# Altium schematic sheet CURRENT_SOURCE.SchDoc
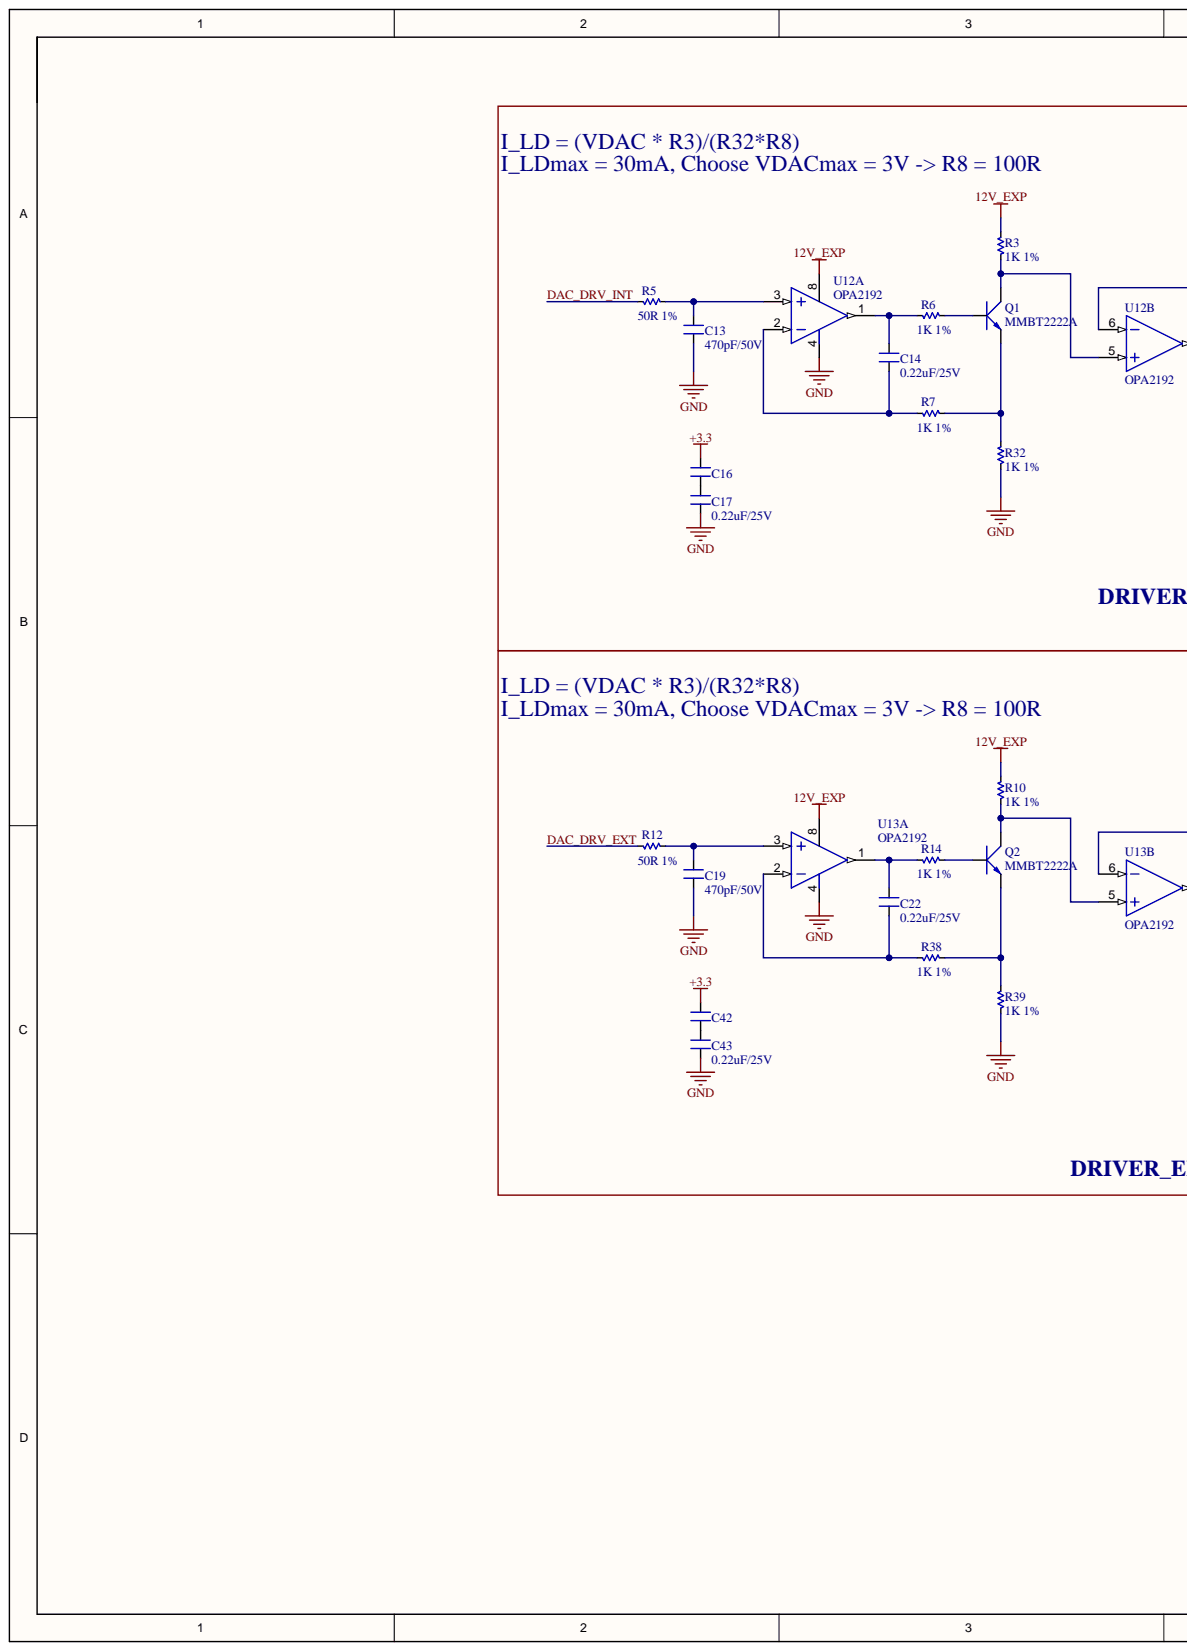
[diagram p0: CURRENT_SOURCE.SchDoc, left]
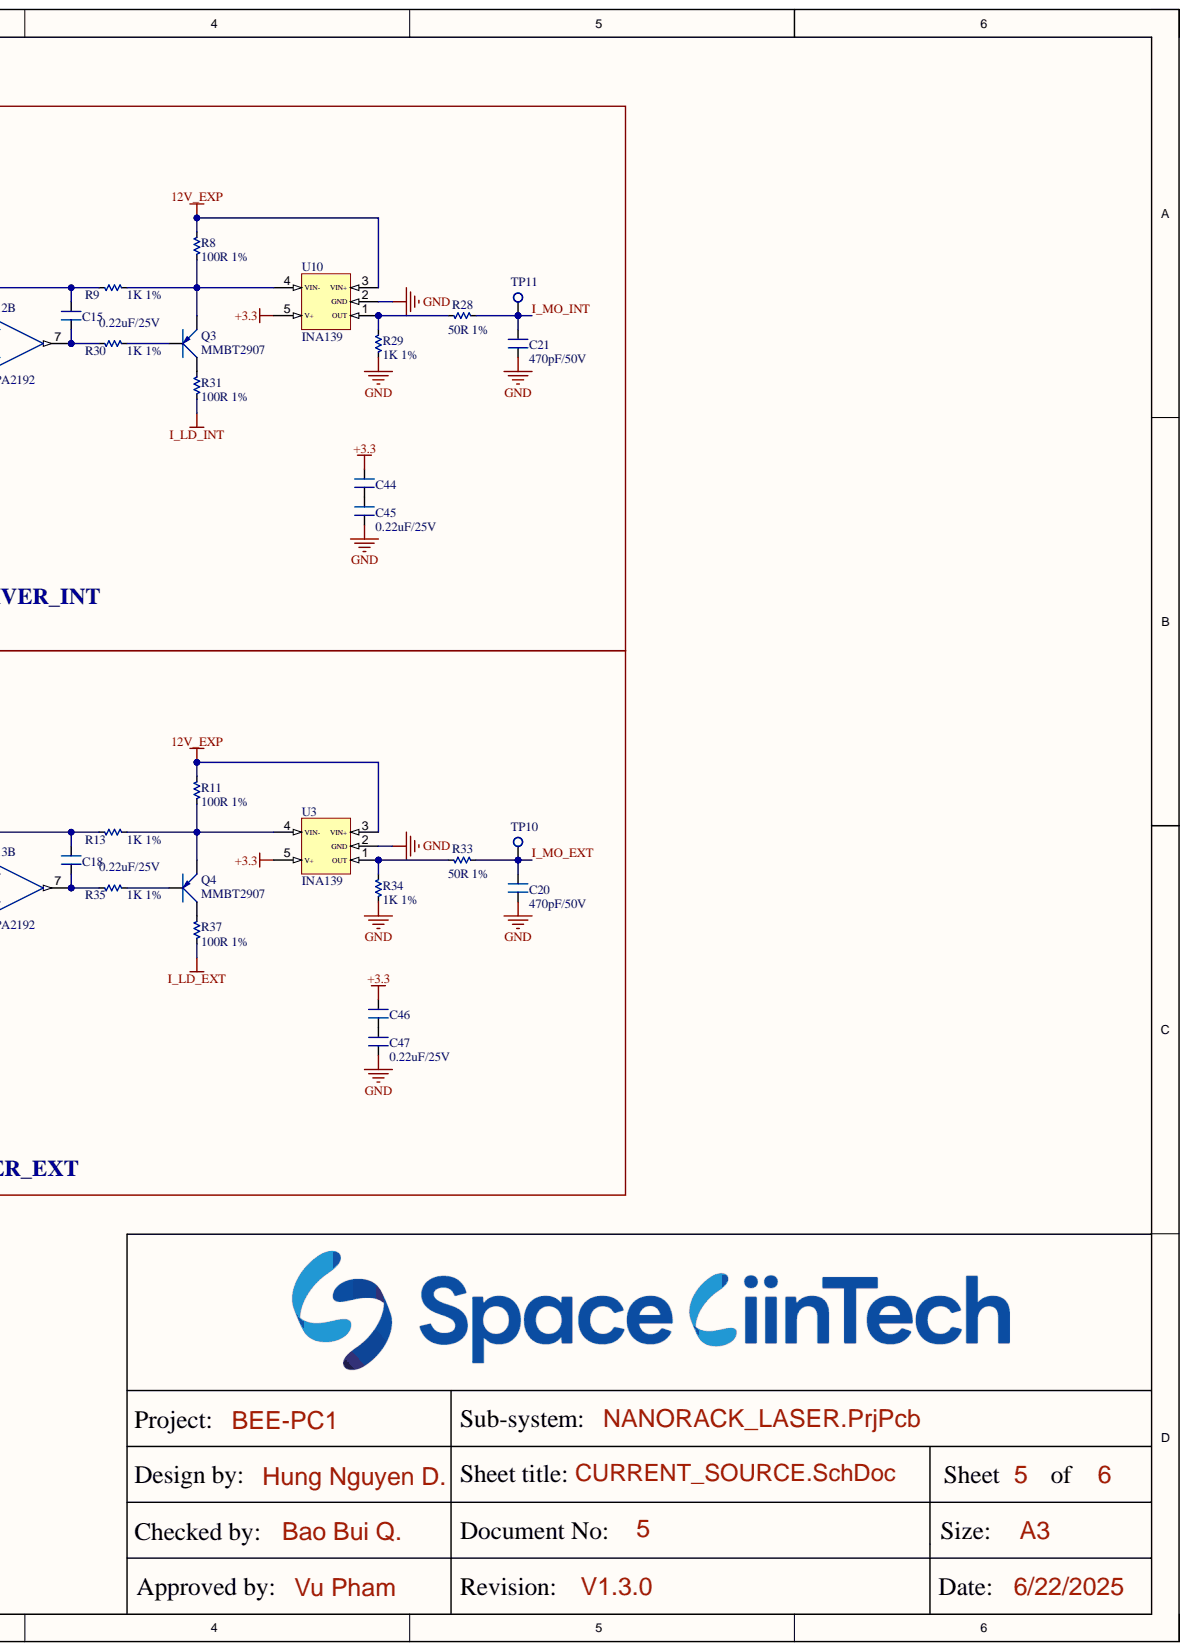
[diagram p1: CURRENT_SOURCE.SchDoc, right]

## Parts
C13  470pF/50V  [SM-0603]
C14  0.22uF/25V  [SM-0603]
C15  0.22uF/25V  [SM-0603]
C16  0.22uF/25V  [SM-0603]
C17  0.22uF/25V  [SM-0603]
C18  0.22uF/25V  [SM-0603]
C19  470pF/50V  [SM-0603]
C20  470pF/50V  [SM-0603]
C21  470pF/50V  [SM-0603]
C22  0.22uF/25V  [SM-0603]
C42  0.22uF/25V  [SM-0603]
C43  0.22uF/25V  [SM-0603]
C44  0.22uF/25V  [SM-0603]
C45  0.22uF/25V  [SM-0603]
C46  0.22uF/25V  [SM-0603]
C47  0.22uF/25V  [SM-0603]
Q1  MMBT2222A  [SOT23-123]
Q2  MMBT2222A  [SOT23-123]
Q3  MMBT2907  [SOT23-123]
Q4  MMBT2907  [SOT23-123]
R3  1K 1%  [RES-SMD-0603]
R5  50R 1%  [RES-SMD-0603]
R6  1K 1%  [RES-SMD-0603]
R7  1K 1%  [RES-SMD-0603]
R8  100R 1%  [RES-SMD-0603]
R9  1K 1%  [RES-SMD-0603]
R10  1K 1%  [RES-SMD-0603]
R11  100R 1%  [RES-SMD-0603]
R12  50R 1%  [RES-SMD-0603]
R13  1K 1%  [RES-SMD-0603]
R14  1K 1%  [RES-SMD-0603]
R28  50R 1%  [RES-SMD-0603]
R29  1K 1%  [RES-SMD-0603]
R30  1K 1%  [RES-SMD-0603]
R31  100R 1%  [RES-SMD-0603]
R32  1K 1%  [RES-SMD-0603]
R33  50R 1%  [RES-SMD-0603]
R34  1K 1%  [RES-SMD-0603]
R35  1K 1%  [RES-SMD-0603]
R37  100R 1%  [RES-SMD-0603]
R38  1K 1%  [RES-SMD-0603]
R39  1K 1%  [RES-SMD-0603]
TP10  Test_Point  [TESTPOINT]  (value not drawn)
TP11  Test_Point  [TESTPOINT]  (value not drawn)
U3  INA139  [SOT23-5]
U10  INA139  [SOT23-5]
U12  OPA2192  [SO8]  (parts A,B)
U13  OPA2192  [SO8]  (parts A,B)

## Nets
12V_EXP: R3.2 R8.2 R10.2 R11.2 U3.3(VIN+) U10.3(VIN+) U12.8 U13.8
+3.3: C16.2 C42.2 C44.2 C46.2 U3.5(V+) U10.5(V+)
GND: C13.1 C17.1 C19.1 C20.1 C21.1 C43.1 C45.1 C47.1 R29.2 R32.1 R34.2 R39.1 U3.2(GND) U10.2(GND) U12.4 U13.4
I_LD_EXT: R37.1
I_LD_INT: R31.1
DAC_DRV_EXT: R12.1
DAC_DRV_INT: R5.1
I_MO_EXT: C20.2 R33.2 TP10.1
I_MO_INT: C21.2 R28.2 TP11.1
NetC13_2: C13.2 R5.2 U12.3
NetC14_1: C14.1 R7.1 U12.2
NetC14_2: C14.2 R6.1 U12.1
NetC15_1: C15.1 R30.2 U12.7
NetC15_2: C15.2 R9.2 U12.6
NetC16_1: C16.1 C17.2
NetC18_1: C18.1 R35.2 U13.7
NetC18_2: C18.2 R13.2 U13.6
NetC19_2: C19.2 R12.2 U13.3
NetC22_1: C22.1 R38.1 U13.2
NetC22_2: C22.2 R14.1 U13.1
NetC42_1: C42.1 C43.2
NetC44_1: C44.1 C45.2
NetC46_1: C46.1 C47.2
NetQ1_1: Q1.1 R6.2
NetQ1_2: Q1.2 R7.2 R32.2
NetQ1_3: Q1.3 R3.1 U12.5
NetQ2_1: Q2.1 R14.2
NetQ2_2: Q2.2 R38.2 R39.2
NetQ2_3: Q2.3 R10.1 U13.5
NetQ3_1: Q3.1 R30.1
NetQ3_2: Q3.2 R8.1 R9.1 U10.4(VIN-)
NetQ3_3: Q3.3 R31.2
NetQ4_1: Q4.1 R35.1
NetQ4_2: Q4.2 R11.1 R13.1 U3.4(VIN-)
NetQ4_3: Q4.3 R37.2
NetR28_1: R28.1 R29.1 U10.1(OUT)
NetR33_1: R33.1 R34.1 U3.1(OUT)

## Library data of the parts
C13-C22 C42-C47 = CM: 0603; Value: 103; Component Kind: Standard; Library Name: Capacitors.SchLib
Q1 Q2 = NPN BJT: Component Kind: Standard; Library Name: Library_HUNG.SchLib
Q3 Q4 = PNP BJT: Component Kind: Standard; Library Name: Library_HUNG.SchLib
R3 R5-R14 R28-R35 R37-R39 = Res-SMD: 0603; Manufacturer: Generic Components; Class: Passive; Component Kind: Standard; Library Name: Library.SchLib; Published: 08/06/2000; Publisher: Altium Hobart Technology Centre
U3 U10 = INA139: SOT23-5; Component Kind: Standard; Library Name: Library_HUNG.SchLib
U12 U13 = LM358: 8SOIC; Value: LM358; Component Kind: Standard; Library Name: Library.SchLib
TP10 TP11 = Test_Point: Component Kind: Standard; Library Name: Library_HUNG.SchLib
